# Altium schematic sheet CAN 2 Ethernet.SchDoc("CAN 2 Ethernet")
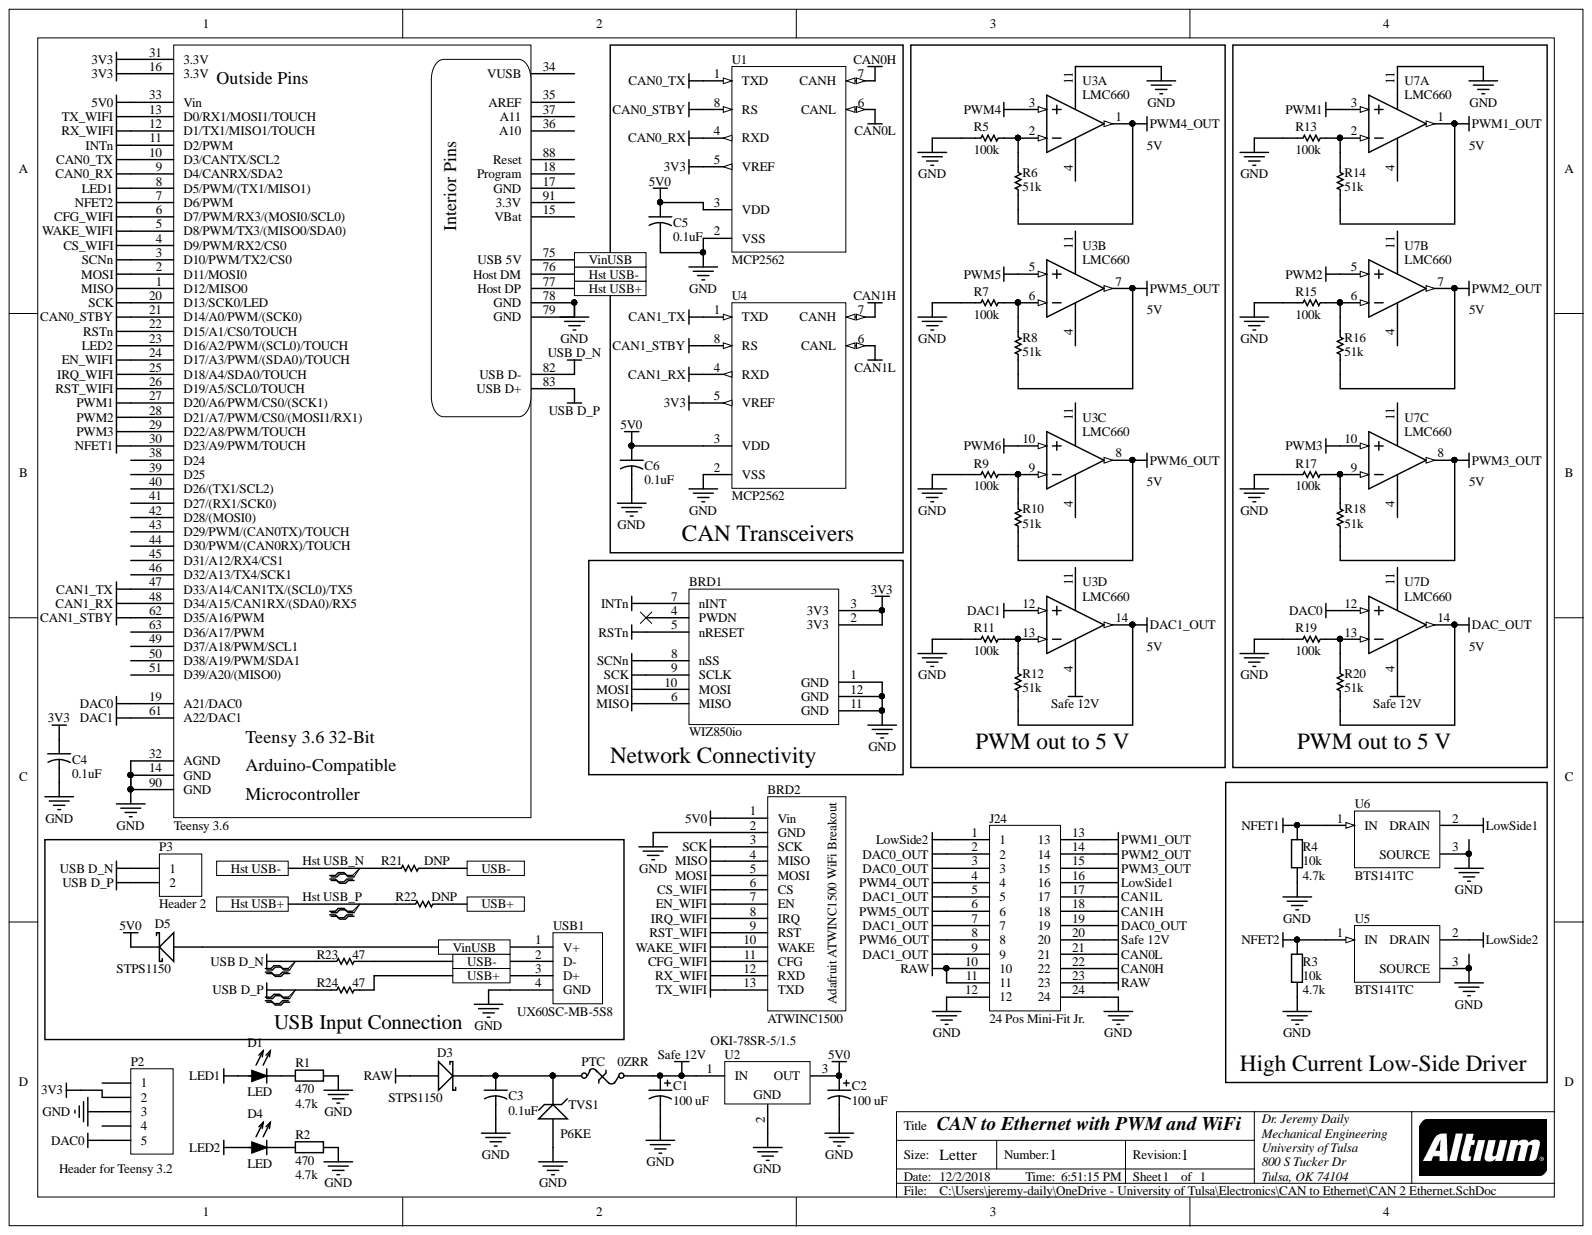
[diagram p0: CAN 2 Ethernet.SchDoc]

## Parts
BRD1  WIZ850io  [Wiz820]
BRD2  ATWINC1500  [Adafruit ATWINC1500]
C1  100 uF  [RB5-10.5]
C2  100 uF  [RB5-10.5]
C3  0.1uF  [CAPR5-4X5]
C4  0.1uF  [CAPR5-4X5]
C5  0.1uF  [CAPR5-4X5]
C6  0.1uF  [CAPR5-4X5]
D1  LED  [LED - 5mm Through Hole]
D3  STPS1150  [DO-204AL]
D4  LED  [LED - 5mm Through Hole]
D5  STPS1150  [DO-204AL]
J24  24 Pos Mini-Fit Jr.  [24 pos Mini-Fit Jr. R/A Header]
P2  Header for Teensy 3.2  [HDR1X5]
P3  Header 2  [HDR1X2]
PTC  0ZRR  [CAPR5-4X5]
R1  470  value 4.7k  [AXIAL-0.4]
R2  470  value 4.7k  [AXIAL-0.4]
R3  10k  value 4.7k  [AXIAL-0.4]
R4  10k  value 4.7k  [AXIAL-0.4]
R5  100k  [AXIAL-0.4]
R6  51k  [AXIAL-0.4]
R7  100k  [AXIAL-0.4]
R8  51k  [AXIAL-0.4]
R9  100k  [AXIAL-0.4]
R10  51k  [AXIAL-0.4]
R11  100k  [AXIAL-0.4]
R12  51k  [AXIAL-0.4]
R13  100k  [AXIAL-0.4]
R14  51k  [AXIAL-0.4]
R15  100k  [AXIAL-0.4]
R16  51k  [AXIAL-0.4]
R17  100k  [AXIAL-0.4]
R18  51k  [AXIAL-0.4]
R19  100k  [AXIAL-0.4]
R20  51k  [AXIAL-0.4]
R21  DNP  [AXIAL-0.4]
R22  DNP  [AXIAL-0.4]
R23  47  [AXIAL-0.4]
R24  47  [AXIAL-0.4]
Teensy_3.6  PartNumber: Teensy 3.6  [Teensy 3.6 Through Hole]  (hidden comment: Teensy 3.6 Through Hole)
TVS1  P6KE  [DIODE-0.7]
U1  MCP2562  [PDIP300-P8]
U2  OKI-78SR-5/1.5  [78XX - Bottom]
U3  LMC660  [TI-N14]  (parts A,B,C,D)
U4  MCP2562  [PDIP300-P8]
U5  BTS141TC  [INF-PG-TO263-3-2_N]
U6  BTS141TC  [INF-PG-TO263-3-2_N]
U7  LMC660  [TI-N14]  (parts A,B,C,D)
USB1  UX60SC-MB-5S8  [USB Mini UX60S]

## Ports
Hst USB+, Hst USB-, USB+, USB-, VinUSB

## Nets
3V3: BRD1.2(3V3) BRD1.3(3V3) C4.1 P2.2 Teensy_3.6.16(3.3V) Teensy_3.6.31(3.3V) U1.5(VREF) U4.5(VREF)
5V0: BRD2.1(Vin) C2.1 C5.1 C6.1 D5.2 Teensy_3.6.33(Vin) U1.3(VDD) U2.3 U4.3(VDD)
CAN0_RX: Teensy_3.6.9(D4/CANRX/SDA2) U1.4(RXD)
CAN0_STBY: Teensy_3.6.21(D14/A0/PWM/(SCK0)) U1.8(RS)
CAN0_TX: Teensy_3.6.10(D3/CANTX/SCL2) U1.1(TXD)
CAN0H: J24.22 U1.7(CANH)
CAN0L: J24.21 U1.6(CANL)
CAN1_RX: Teensy_3.6.48(D34/A15/CAN1RX/(SDA0)/RX5) U4.4(RXD)
CAN1_STBY: Teensy_3.6.62(D35/A16/PWM) U4.8(RS)
CAN1_TX: Teensy_3.6.47(D33/A14/CAN1TX/(SCL0)/TX5) U4.1(TXD)
CAN1H: J24.18 U4.7(CANH)
CAN1L: J24.17 U4.6(CANL)
CFG_WIFI: BRD2.11(CFG) Teensy_3.6.6(D7/PWM/RX3/(MOSI0/SCL0))
CS_WIFI: BRD2.6(CS) Teensy_3.6.4(D9/PWM/RX2/CS0)
DAC0: P2.5 Teensy_3.6.19(A21/DAC0) U7.12
DAC0_OUT: J24.2 J24.3 J24.19
DAC1: Teensy_3.6.61(A22/DAC1) U3.12
DAC1_OUT: J24.5 J24.7 J24.9 R12.2 U3.14
DAC_OUT: R20.2 U7.14
EN_WIFI: BRD2.7(EN) Teensy_3.6.24(D17/A3/PWM/(SDA0)/TOUCH)
GND: BRD1.1(GND) BRD1.11(GND) BRD1.12(GND) BRD2.2(GND) C1.2 C2.2 C3.2 C4.2 C5.2 C6.2 J24.12 J24.24 P2.3 R1.2 R2.2 R3.1 R4.1 R5.2 R7.2 R9.2 R11.2 R13.2 R15.2 R17.2 R19.2 Teensy_3.6.14(GND) Teensy_3.6.32(AGND) Teensy_3.6.78(GND) Teensy_3.6.79(GND) Teensy_3.6.90(GND) TVS1.1 U1.2(VSS) U2.2 U3.11 U4.2(VSS) U5.3 U6.3 U7.11 USB1.4(GND)
INTn: BRD1.7(nINT) Teensy_3.6.11(D2/PWM)
IRQ_WIFI: BRD2.8(IRQ) Teensy_3.6.25(D18/A4/SDA0/TOUCH)
LED1: D1.1 Teensy_3.6.8(D5/PWM/(TX1/MISO1))
LED2: D4.1 Teensy_3.6.23(D16/A2/PWM/(SCL0)/TOUCH)
LowSide1: J24.16 U6.2
LowSide2: J24.1 U5.2
MISO: BRD1.6(MISO) BRD2.4(MISO) Teensy_3.6.1(D12/MISO0)
MOSI: BRD1.10(MOSI) BRD2.5(MOSI) Teensy_3.6.2(D11/MOSI0)
NFET1: R4.2 Teensy_3.6.30(D23/A9/PWM/TOUCH) U6.1
NFET2: R3.2 Teensy_3.6.7(D6/PWM) U5.1
PWM1: Teensy_3.6.27(D20/A6/PWM/CS0/(SCK1)) U7.3
PWM1_OUT: J24.13 R14.2 U7.1
PWM2: Teensy_3.6.28(D21/A7/PWM/CS0/(MOSI1/RX1)) U7.5
PWM2_OUT: J24.14 R16.2 U7.7
PWM3: Teensy_3.6.29(D22/A8/PWM/TOUCH) U7.10
PWM3_OUT: J24.15 R18.2 U7.8
PWM4: U3.3
PWM4_OUT: J24.4 R6.2 U3.1
PWM5: U3.5
PWM5_OUT: J24.6 R8.2 U3.7
PWM6: U3.10
PWM6_OUT: J24.8 R10.2 U3.8
RAW: D3.1 J24.10 J24.11 J24.23
RST_WIFI: BRD2.9(RST) Teensy_3.6.26(D19/A5/SCL0/TOUCH)
RSTn: BRD1.5(nRESET) Teensy_3.6.22(D15/A1/CS0/TOUCH)
RX_WIFI: BRD2.12(RXD) Teensy_3.6.12(D1/TX1/MISO1/TOUCH)
Safe 12V: C1.1 J24.20 PTC.2 U2.1 U3.4 U7.4
SCK: BRD1.9(SCLK) BRD2.3(SCK) Teensy_3.6.20(D13/SCK0/LED)
SCNn: BRD1.8(nSS) Teensy_3.6.3(D10/PWM/TX2/CS0)
TX_WIFI: BRD2.13(TXD) Teensy_3.6.13(D0/RX1/MOSI1/TOUCH)
USB D_N: P3.1 R23.1 Teensy_3.6.82(USB_D-)
USB D_P: P3.2 R24.1 Teensy_3.6.83(USB_D+)
WAKE_WIFI: BRD2.10(WAKE) Teensy_3.6.5(D8/PWM/TX3/(MISO0/SDA0))
Hst USB_N: R21.1 Teensy_3.6.76(Host_DM)
Hst USB_P: R22.1 Teensy_3.6.77(Host_DP)
VinUSB: D5.1 Teensy_3.6.75(USB_5V) USB1.1(V+) ; port VinUSB
NetC3_1: C3.1 D3.2 PTC.1 TVS1.2
NetD1_2: D1.2 R1.1
NetD4_2: D4.2 R2.1
NetR5_1: R5.1 R6.1 U3.2
NetR7_1: R7.1 R8.1 U3.6
NetR9_1: R9.1 R10.1 U3.9
NetR11_1: R11.1 R12.1 U3.13
NetR13_1: R13.1 R14.1 U7.2
NetR15_1: R15.1 R16.1 U7.6
NetR17_1: R17.1 R18.1 U7.9
NetR19_1: R19.1 R20.1 U7.13
NetR21_2: R21.2 R23.2 USB1.2(D-)
NetR22_2: R22.2 R24.2 USB1.3(D+)

Not connected: BRD1 4(PWDN); P2 1 4; Teensy_3.6 15(VBat) 17(GND) 18(Program) 34(VUSB) 35(AREF) 36(A10) 37(A11) 38(D24) 39(D25) 40(D26/(TX1/SCL2)) 41(D27/(RX1/SCK0)) 42(D28/(MOSI0)) 43(D29/PWM/(CAN0TX)/TOUCH) 44(D30/PWM/(CAN0RX)/TOUCH) 45(D31/A12/RX4/CS1) 46(D32/A13/TX4/SCK1) 49(D37/A18/PWM/SCL1) 50(D38/A19/PWM/SDA1) 51(D39/A20/(MISO0)) 63(D36/A17/PWM) 88(Reset) 91(3.3V)

## Library data of the parts
BRD1 = WIZ820io: Ethernet Modules W5200 & MAG JACK ioPLATFORM MODULE; Manufacturer: WIZNET; Supplier Part Number 1: 950-WIZ820IO; Supplier 1: Mouser; Part Number: WIZ820io; Library Name: TU Custom Parts Schematics.SchLib; Component Kind: Standard
BRD2 = Adafruit ATWINC1500: Adafruit ATWINC1500 WiFi Breakout, PRODUCT ID: 2999; Library Name: TU Custom Parts Schematics.SchLib; Component Kind: Standard
C1 C2 = Cap Pol1: 100µF 160V Aluminum Electrolytic Capacitors Radial, Can  8000 Hrs @ 105°C; PartNumber: UPW2C101MHD; Manufacturer: Nichicon; Supplier Part Number 1: 493-1998-ND; Supplier 1: Digi-Key; Simulation: CAP; Signal Integrity: Cap Pol; Library Name: Miscellaneous Devices.IntLib; Component Kind: Standard
C3-C6 = Cap2: Capacitor; PartNumber: C330C104K2R5TA; Manufacturer: KEMET; Supplier Part Number 1: 399-4387-ND; Supplier 1: Digi-Key; Simulation: CAP; Signal Integrity: Cap; Library Name: Miscellaneous Devices.IntLib; Component Kind: Standard
D1 D4 = LED: Light Emmitting Diode; Library Name: TU Custom Parts Schematics.SchLib; Component Kind: Standard
D3 D5 = Diode 11DQ03: Diode Schottky 150V 1A Through Hole DO-41; Manufacturer: STMicroelectronics; Supplier Part Number 1: 497-2447-1-ND; Supplier 1: Digi-Key; Simulation: 11DQ03; PartNumber: STPS1150; Library Name: Miscellaneous Devices.IntLib; Component Kind: Standard
J24 = 24 Pos Header: Manufacturer: Molex; Supplier Part Number 2: 538-39-30-1240; Supplier 2: Mouser; Supplier 1: Digi-Key; PartNumber: 39-30-1240; Library Name: Molex Mini-Fit Jr.IntLib; Component Kind: Standard
P2 = Header 5: Header, 5-Pin; Signal Integrity: Connector; Library Name: Miscellaneous Connectors.IntLib; LatestRevisionNote: Re-released for DXP Platform.; LatestRevisionDate: 17-Jul-2002; Component Kind: Standard; Publisher: Altium Limited
P3 = Header 2: Header, 2-Pin; Signal Integrity: Connector; Library Name: Miscellaneous Connectors.IntLib; Component Kind: Standard
PTC = Fuse Thermal: Polymeric PTC Resettable Fuse 72V 200mA Ih Through Hole Radial, Disc; PartNumber: RXEF020; Manufacturer: Littelfuse Inc.; Supplier Part Number 1: RXEF020HF-ND; Supplier 1: Digi-Key; Library Name: Miscellaneous Devices.IntLib; Component Kind: Standard
R1-R4 = Res2: Resistor; Simulation: RESISTOR; Signal Integrity: Res; Published: 8-Jun-2000; PackageReference: AXIAL-0.4; PackageDescription: Axial Device, Thru-Hole; 2 Leads; 0.4 in Pin Spacing; Library Name: Miscellaneous Devices.IntLib; LatestRevisionNote: Re-released for DXP Platform.; LatestRevisionDate: 17-Jul-2002; Component Kind: Standard; Publisher: Altium Limited
R5 R7 R9 R11 R13 R15 R17 R19 = Chip Resistor: 100 kOhms ±1% 0.1W, 1/10W Chip Resistor 0603 (1608 Metric) Automotive AEC-Q200 Thick Film; PartNumber: ERJ-3EKF1003V; Manufacturer: Panasonic Electronic Components; Alternative: Acceptable; Supplier Part Number 1: P100KHCT-ND; Supplier 1: Digi-Key; Library Name: SSS Integrated Library.IntLib; Component Kind: Standard
R6 R8 R10 R12 R14 R16 R18 R20 = Chip Resistor: RES SMD 51K OHM 1% 1/10W 0603; PartNumber: ERJ-3EKF5102V; Manufacturer: Panasonic Electronic Components; Alternative: Acceptable; Supplier Part Number 1: P51.0KHCT-ND; Supplier 1: Digi-Key; Library Name: SSS Integrated Library.IntLib; Component Kind: Standard
R21 R22 = Chip Resistor: RES SMD 47 OHM 1% 1/10W 0603; PartNumber: ERJ-3EKF47R0V; Manufacturer: Panasonic Electronic Components; Supplier Part Number 1: P47.0HCT-ND; Supplier 1: Digi-Key; Library Name: SSS Integrated Library.IntLib; Component Kind: Standard
R23 R24 = Chip Resistor: ±1% 0.1W, 1/10W Chip Resistor 0603 (1608 Metric) Automotive AEC-Q200, High Voltage Thick Film; PartNumber: KTR03EZPF47R0; Manufacturer: Rohm Semiconductor; Supplier Part Number 1: RHM47AYCT-ND; Supplier 1: Digi-Key; Library Name: SSS Integrated Library.IntLib; Component Kind: Standard
Teensy_3.6 = Teensy 3.6 Through Hole: 32 Bit Arduino-Compatible Microcontroller; Manufacturer: PRJC; Supplier Part Number 1: DEV-13736; Supplier 1: Sparkfun; Library Name: Teensy.IntLib; Component Kind: Standard
TVS1 = D Zener: TVS DIODE 25.6V 41.4V DO15; PartNumber: P6KE30CA; Manufacturer: ON Semiconductor; Supplier Part Number 1: P6KE30CAFSCT-ND; Supplier 1: Digi-Key; Simulation: ZENER; Signal Integrity: D Zener; Library Name: Miscellaneous Devices.IntLib; Component Kind: Standard
U1 U4 = MCP2551-E/P: High-Speed CAN Transceiver, 8-Pin PDIP, Extended Temperature; Manufacturer: Microchip; Supplier Part Number 1: MCP2551-E/P-ND; Supplier 1: Digi-Key; PartNumber: MCP2551-E/P; Library Name: Microchip Interface.IntLib; Component Kind: Standard
U2 = LM78M05CT/NOPB: Linear Regulator Replacement DC DC Converter 1 Output 5V    1.5A 7V - 36V Input; PartNumber: OKI-78SR-5/1.5-W36-C; Manufacturer: National Semiconductor; Supplier Part Number 1: 811-2196-5-ND; Supplier 1: Digi-Key; Library Name: National Semiconductor LDO.IntLib; Component Kind: Standard
U3 U7 = TL064CPW: Quad Low-Power JFET-Input General-Purpose Operational Amplifier, 7 to 36 V, 0 to 70 degC, 14-pin SOP (PW14), Green (RoHS & no Sb/Br); PartNumber: TLV4171IPWR; Manufacturer: Texas Instruments; Alternative: None; Supplier Part Number 1: 296-44866-1-ND; Supplier 1: Digi-Key; Library Name: TI Amplifiers and Linear Operational Amplifier General Purpose.IntLib; Component Kind: Standard
U5 U6 = BTS141TC: Smart Low-Side Power Switch HITFET, 60 V VDS, 12 A ID, -40 to 150 degC, PG-TO263-3-2, Reel, Green; Manufacturer: Infineon; Supplier Part Number 1: BTS141TCTR-ND; Supplier 1: Digi-Key; PartNumber: BTS141TC; Library Name: Infineon_Power_Smart_Low-Side_&_High-Side_Switches.IntLib; Component Kind: Standard
USB1 = USB-Rugged: USB - mini B USB 2.0 Receptacle Connector 5 Position Surface Mount, Right Angle; Through Hole; Manufacturer: Hirose; Supplier Part Number 1: H11589CT-ND; Supplier 1: Digi-Key; PartNumber: UX60SC-MB-5S8; Library Name: SSS Integrated Library.IntLib; Component Kind: Standard
